# Altium schematic sheet 电机驱动模块.SchDoc(电机驱动模块)
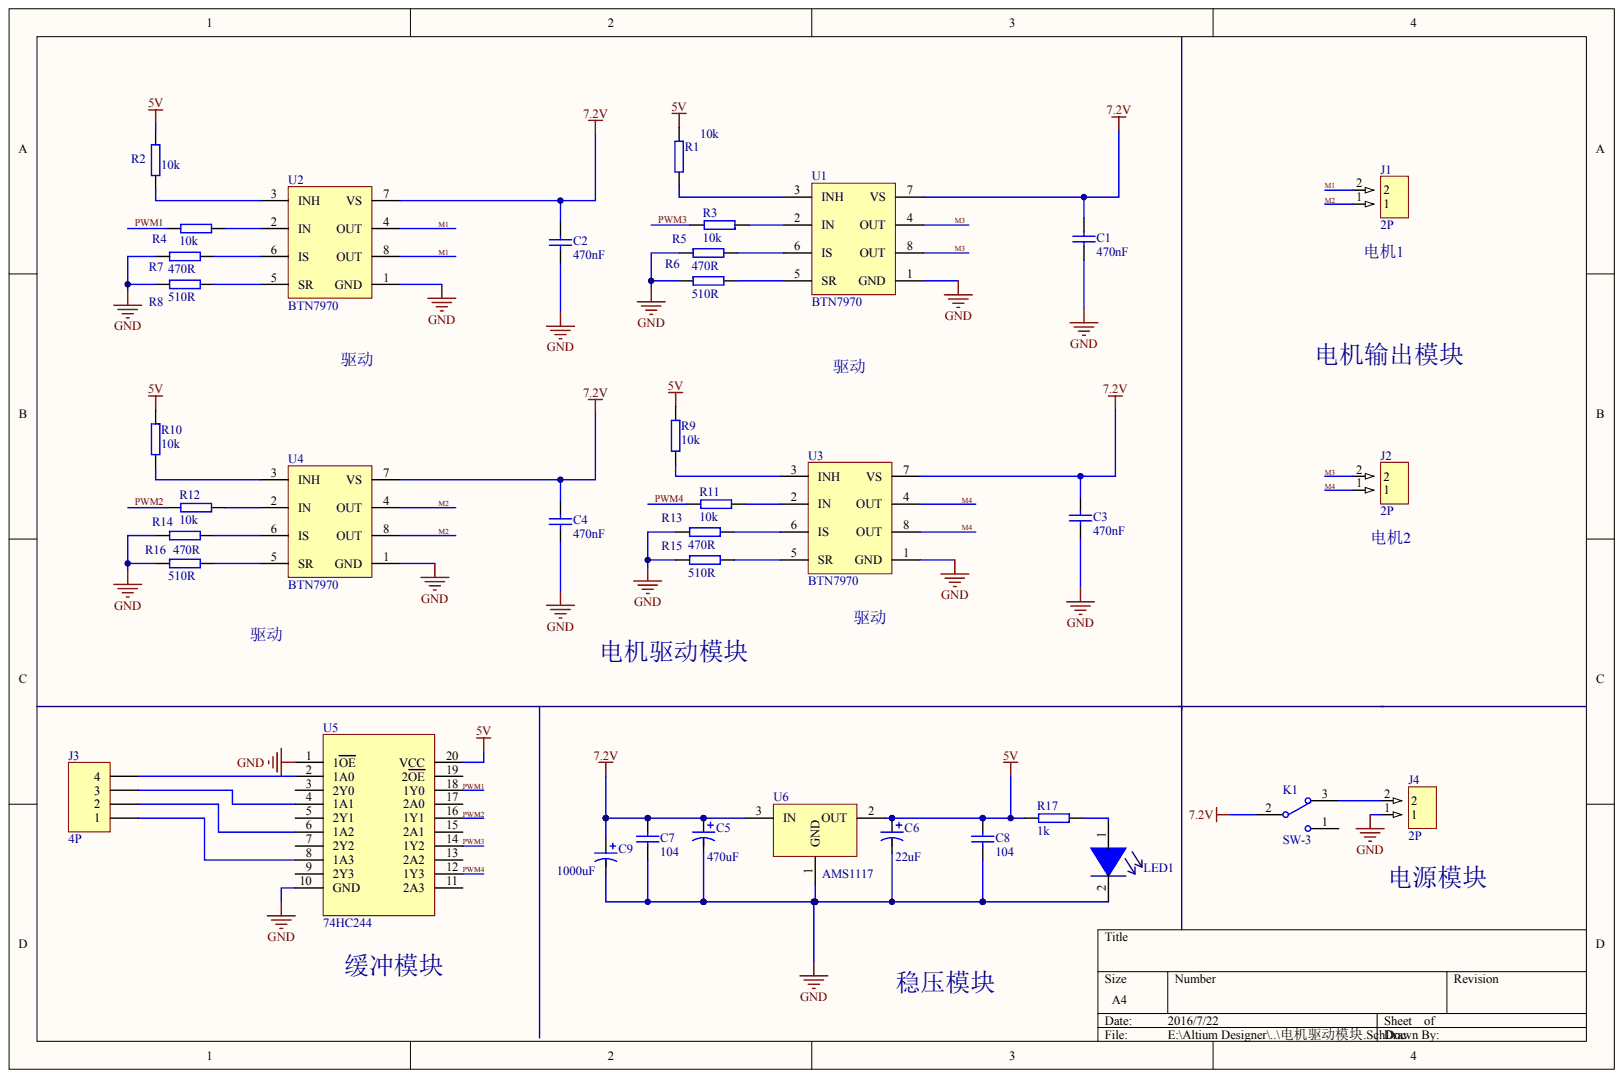
[diagram p0: 电机驱动模块.SchDoc]

## Parts
C1  470nF
C2  470nF
C3  470nF
C4  470nF
C5  470uF
C6  22uF
C7  104
C8  104
C9  1000uF
J1  2P
J2  2P
J3  4P
J4  2P
K1  SW-3
LED1  发光二极管  (value not drawn)
R1  10k
R2  10k
R3  10k
R4  10k
R5  470R
R6  510R
R7  470R
R8  510R
R9  10k
R10  10k
R11  10k
R12  10k
R13  470R
R14  470R
R15  510R
R16  510R
R17  1k
U1  BTN7970
U2  BTN7970
U3  BTN7970
U4  BTN7970
U5  74HC244
U6  AMS1117

## Nets
5V: C6.1 C8.2 R1.2 R2.2 R9.2 R10.2 R17.1 U5.20(VCC) U6.2
7.2V: C1.2 C2.2 C3.2 C4.2 C5.1 C7.2 C9.1 K1.2 U1.7(VS) U2.7(VS) U3.7(VS) U4.7(VS) U6.3
GND: C1.1 C2.1 C3.1 C4.1 C5.2 C6.2 C7.1 C8.1 C9.2 J4.1 LED1.2 R5.2 R6.2 R7.2 R8.2 R13.2 R14.2 R15.2 R16.2 U1.1(GND) U2.1(GND) U3.1(GND) U4.1(GND) U5.1(1OE) U5.10(GND) U6.1
M1: J1.2 U2.4(OUT) U2.8(OUT)
M2: J1.1 U4.4(OUT) U4.8(OUT)
M3: J2.2 U1.4(OUT) U1.8(OUT)
M4: J2.1 U3.4(OUT) U3.8(OUT)
PWM1: R4.1 U5.18(1Y0)
PWM2: R12.1 U5.16(1Y1)
PWM3: R3.1 U5.14(1Y2)
PWM4: R11.1 U5.12(1Y3)
NetJ3_1: J3.1 U5.8(1A3)
NetJ3_2: J3.2 U5.6(1A2)
NetJ3_3: J3.3 U5.4(1A1)
NetJ3_4: J3.4 U5.2(1A0)
NetJ4_2: J4.2 K1.3
NetLED1_1: LED1.1 R17.2
NetR1_1: R1.1 U1.3(INH)
NetR2_1: R2.1 U2.3(INH)
NetR3_2: R3.2 U1.2(IN)
NetR4_2: R4.2 U2.2(IN)
NetR5_1: R5.1 U1.6(IS)
NetR6_1: R6.1 U1.5(SR)
NetR7_1: R7.1 U2.6(IS)
NetR8_1: R8.1 U2.5(SR)
NetR9_1: R9.1 U3.3(INH)
NetR10_1: R10.1 U4.3(INH)
NetR11_2: R11.2 U3.2(IN)
NetR12_2: R12.2 U4.2(IN)
NetR13_1: R13.1 U3.6(IS)
NetR14_1: R14.1 U4.6(IS)
NetR15_1: R15.1 U3.5(SR)
NetR16_1: R16.1 U4.5(SR)

Not connected: K1 1; U5 3(2Y0) 5(2Y1) 7(2Y2) 9(2Y3) 11(2A3) 13(2A2) 15(2A1) 17(2A0) 19(2OE)
